# Altium schematic sheet Sheet2.SchDoc
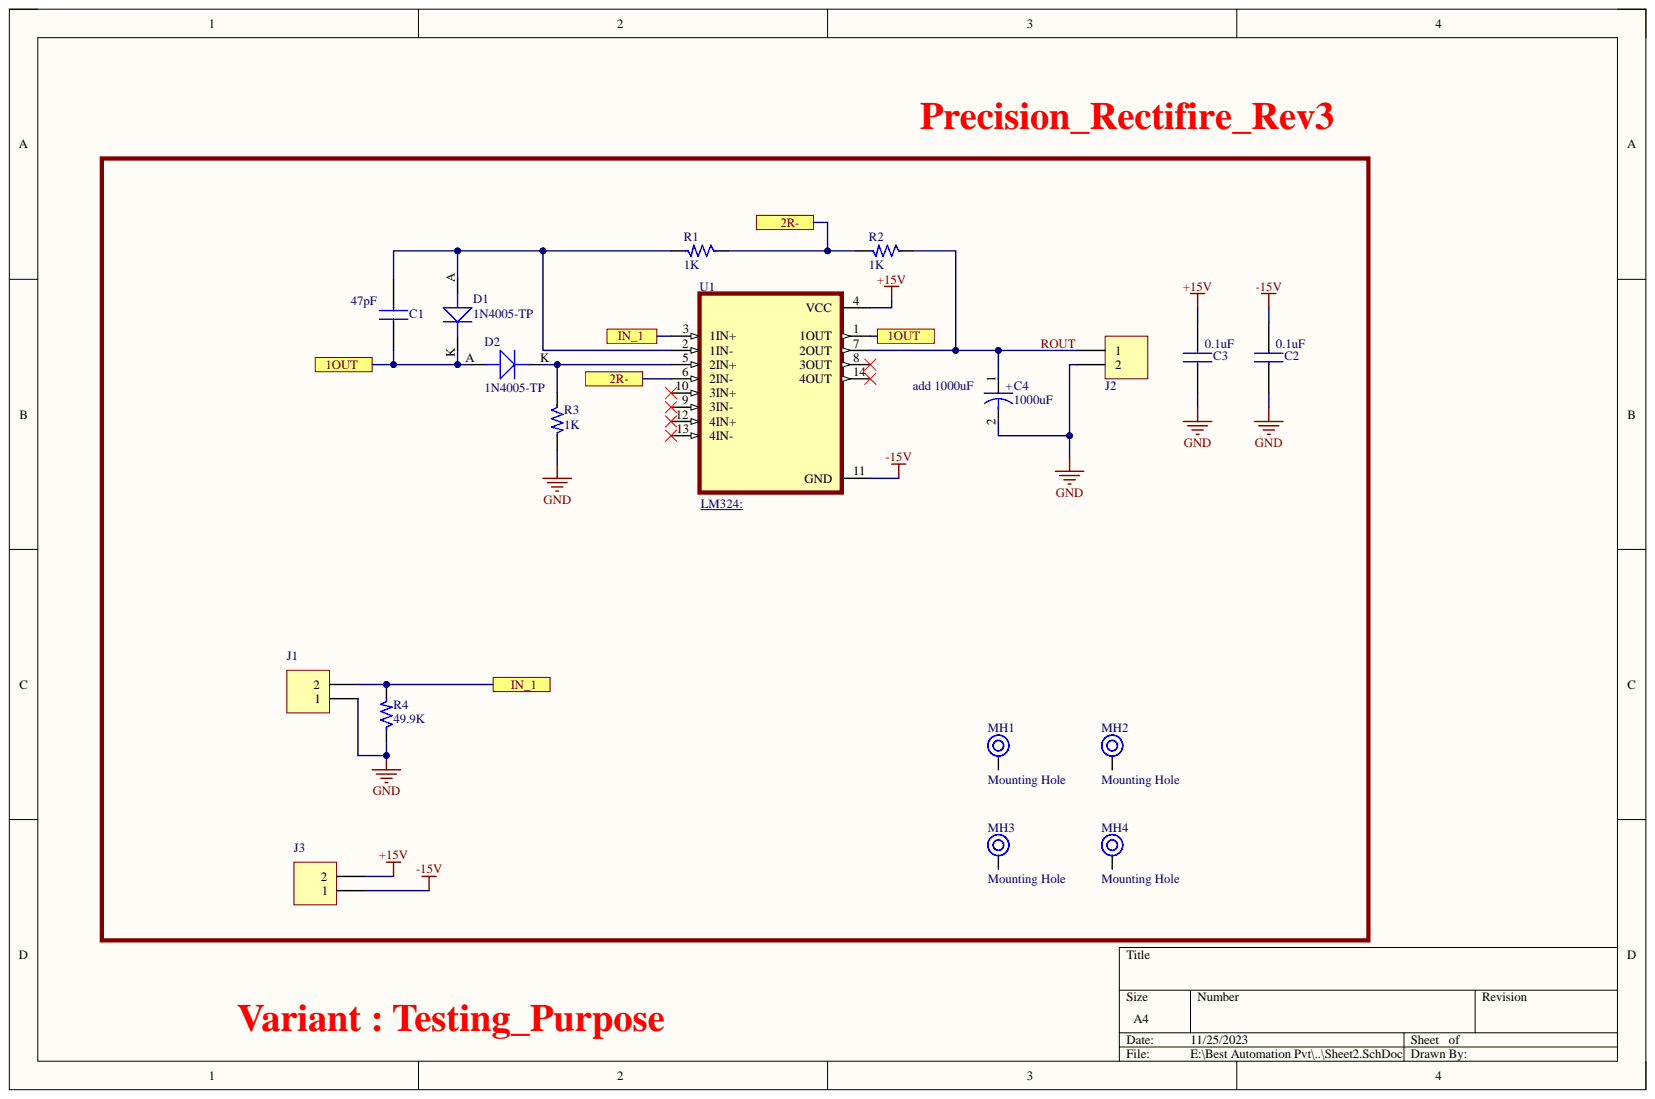
[diagram p0: Sheet2.SchDoc]

## Parts
C1  47pF  [CAP_1206]
C2  0.1uF  [CAP_1206]
C3  0.1uF  [CAP_1206]
C4  1000uF  [ECAP_P196_D511_H984_TH]
D1  1N4005-TP  [DIO_TO_220_2]
D2  1N4005-TP  [DIO_TO_220_2]
J1  py  [CON2_P196_1729128_TH_ST]  (value not drawn)
J2  py  [CON2_P196_1729128_TH_ST]  (value not drawn)
J3  py  [CON2_P196_1729128_TH_ST]  (value not drawn)
MH1  Mounting Hole  [MOUNTING]
MH2  Mounting Hole  [MOUNTING]
MH3  Mounting Hole  [MOUNTING]
MH4  Mounting Hole  [MOUNTING]
R1  1K  [RES_1206]
R2  1K  [RES_1206]
R3  1K  [RES_1206]
R4  49.9K  [RES_539.3_TH]
U1  (no value)  [DIP794W45P254L1969H508Q14]

## Ports
1OUT, 2R-, IN_1

## Nets
+15V: C3.2 J3.2 U1.4(VCC)
-15V: C2.2 J3.1 U1.11(GND)
GND: C2.1 C3.1 C4.2 J1.1 J2.2 R3.2 R4.1
1OUT: C1.1 D1.K D2.A U1.1(1OUT) ; port 1OUT
2R-: R1.1 R2.2 U1.6(2IN-) ; port 2R-
IN_1: J1.2 R4.2 U1.3(1IN+) ; port IN_1
ROUT: C4.1 J2.1 R2.1 U1.7(2OUT)
NetC1_2: C1.2 D1.A R1.2 U1.2(1IN-)
NetD2_K: D2.K R3.1 U1.5(2IN+)

Not connected: MH1 1; MH2 1; MH3 1; MH4 1; U1 8(3OUT) 9(3IN-) 10(3IN+) 12(4IN+) 13(4IN-) 14(4OUT)

## Library data of the parts
C1-C3 = K104Z15Y5VE5TL2: Vishay K 100nF Ceramic Multilayer Capacitor, 100 V dc, +85C, Y5V Dielectric, +80 %, -20 %; Geometry.Height: 5.6mm; Component Kind: Standard; Datasheet Link: http://www.vishay.com/docs/45171/kseries.pdf; Library Name: Schlib1.SchLib; Manufacturer_Name: Vishay; Manufacturer_Part_Number: K104Z15Y5VE5TL2; Mouser Part Number: 594-K104Z15Y5VE5TL2; Mouser Price/Stock: https://www.mouser.co.uk/ProductDetail/Vishay-BC-Components/K104Z15Y5VE5TL2?qs=dZIvsO2gZWIlp1twxs%2FroQ%3D%3D
C4 = CAP-P_1000uF-50V-20%-TH: 1000µF 50V Aluminum Electrolytic Capacitors Radial, Can 2000 Hrs @ 85°C; Alternate Part Number-1: NRSS102M50V 12.5X25TBF; Manufacturer: Nichicon; Manufacturer Part Number: UVR1H102MHD1TO; Case/Package: Radial-TH; Component Kind: Standard; Library Name: ESTEEMPCBLIB.SchLib; Supplier Part Number-1: UVR1H102MHD1TO; Supplier-1: SP ROAD
D1 D2 = DIO_600V-1A-DO-41: Diode Standard 600V 1A Through Hole DO-41; Alternate Part Number-1: NA; Manufacturer: Vishay Semiconductors; Case/Package: DO-41; Component Kind: Standard; Library Name: precision.SchLib; Manufacturer Part Number: 1N4005-TP; Supplier Part Number-1: 1N4007; Supplier-1: SIRICOM
J1-J3 = CON-TB_2X1-196-ST-TH: 2 Pins, 1 Row 5mm Pitch, straight Connector, male; Alternate Part Number-1: NA; Manufacturer: Phoenix Contact; Manufacturer Part Number: MKDSN1,5/2; Case/Package: TH-CON; Component Kind: Standard; Library Name: ESTEEMPCBLIB.SchLib; Supplier Part Number-1: MKDSN1,5/2; Supplier-1: SP ROAD
MH1-MH4 = MH_3.5H_6D: Alternate Part Number-1: NA; Case/Package: TH; Component Kind: Standard; Description: Mounting Hole; Library Name: ESTEEMPCBLIB.SchLib; Manufacturer: NA; Manufacturer Part Number: NA; Supplier Part Number-1: NA; Supplier-1: NA
R1-R4 = RES_10R-0.25W-5%-1206: 10 Ohms ±5% 0.25W, 1/4W Chip Resistor 1206 (3216 Metric) Automotive AEC-Q200 Thick Film; Alternate Part Number-1: NA; Manufacturer: Yageo; Manufacturer Part Number: RMCF1206JT10R0; Case/Package: 1206; Component Kind: Standard; Supplier Part Number-1: WR12X10R0FTL; Supplier-1: SIRICOM; Library Name: MFDC_rev1.SchLib
U1 = LM324DR: 4-Channel industry standard operational amplifier 14-SOIC 0 to 70; MF: Texas Instruments; Arrow_Electronics-Purchase-URL: https://snapeda.com/shop?store=Arrow+Electronics&id=1818; Availability: Good; Component Kind: Standard; DigiKey-Purchase-URL: https://snapeda.com/shop?store=DigiKey&id=1818; Library Name: ESTEEMPCBLIB.SchLib; Mouser-Purchase-URL: https://snapeda.com/shop?store=Mouser&id=1818; MP: LM324DR; Package: SOIC-14 Texas Instruments; Price: None; SnapEDA_Link: https://www.snapeda.com/parts/LM324DR/Texas%20Instruments/view-part/1818/?ref=snap; Texas_Instruments-Purchase-URL: https://snapeda.com/shop?store=Texas+Instruments&id=1818
